# Altium schematic sheet Power.SchDoc(Power)
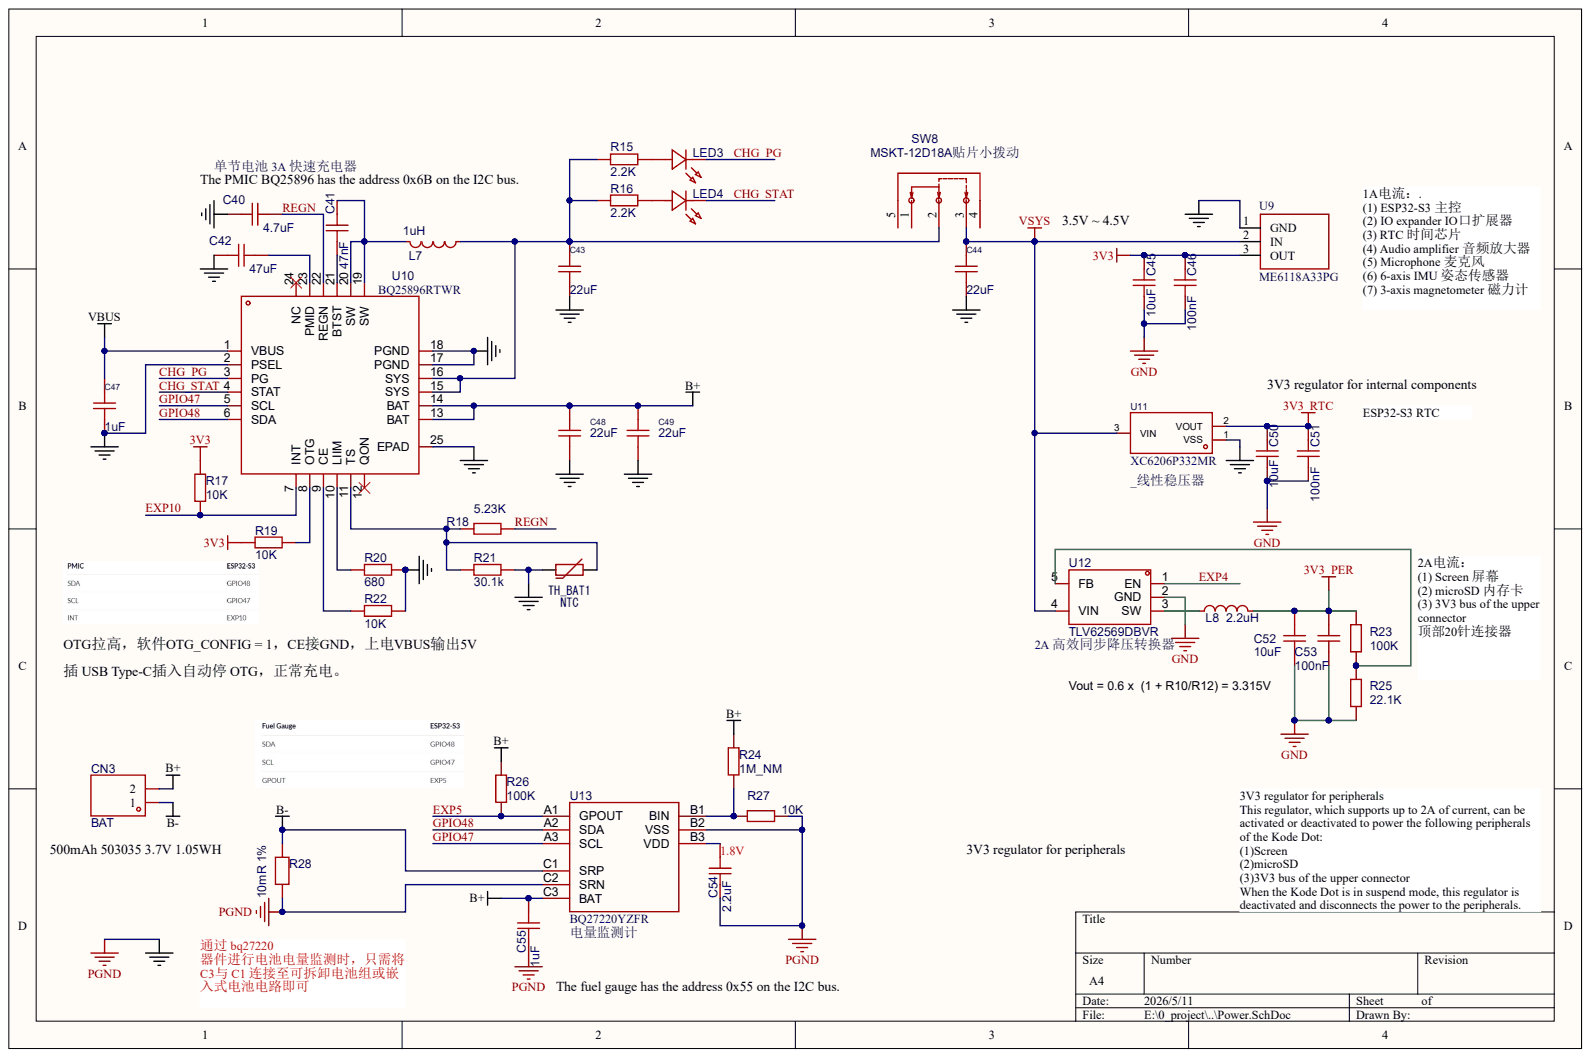
[diagram p0: Power.SchDoc]

## Parts
C40  4.7uF
C41  47nF
C42  47uF
C43  22uF
C44  22uF
C45  10uF
C46  100nF
C47  1uF
C48  value 22uF  (hidden comment: 1uF)
C49  value 22uF  (hidden comment: 1uF)
C50  10uF
C51  100nF
C52  10uF
C53  100nF
C54  2.2uF
C55  1uF
CN3  BAT
L7  1uH
L8  2.2uH
LED3  XL-1005UGC  (value not drawn)
LED4  XL-1005UGC  (value not drawn)
R15  2.2K
R16  2.2K
R17  10K
R18  5.23K
R19  10K
R20  680
R21  30.1k
R22  10K
R23  100K
R24  1M_NM
R25  22.1K
R26  100K
R27  10K
R28  10mR 1%
SW8  MSKT-12D18A贴片小拨动
TH_BAT1  NTC
U9  ME6118A33PG
U10  BQ25896RTWR
U11  XC6206P332MR
U12  TLV62569DBVR  Output Current (Max): 2A
U13  BQ27220YZFR

## Nets
3V3: C45.2 C46.2 R17.2 R19.1 U9.3
3V3_PER: C52.2 C53.2 L8.2 R23.1
3V3_RTC: C50.2 C51.2 U11.2
B+: C48.2 C49.2 C55.2 CN3.2 R24.2 R26.1 U10.13(BAT) U10.14(BAT) U13.C3(BAT)
B-: CN3.1 R28.2 U13.C1(SRP)
GND: C40.1 C42.1 C43.1 C44.1 C45.1 C46.1 C47.1 C48.1 C49.1 C50.1 C51.1 C52.1 C53.1 C54.1 C55.1 R20.2 R21.2 R22.2 R25.2 R27.2 R28.1 TH_BAT1.1 U9.1 U10.2(PSEL) U10.17(PGND) U10.18(PGND) U10.25(EPAD) U11.1 U12.2(GND) U13.B2(VSS) U13.C2(SRN)
VBUS: C47.2 U10.1(VBUS)
VSYS: C44.2 SW8.3 U9.2 U11.3 U12.4(VIN)
CHG_PG: LED3.1 U10.3(PG)
CHG_STAT: LED4.1 U10.4(STAT)
EXP4: U12.1(EN)
EXP5: R26.2 U13.A1(GPOUT)
EXP10: R17.1 U10.7(INT)
GPIO47: U10.5(SCL) U13.A3(SCL)
GPIO48: U10.6(SDA) U13.A2(SDA)
REGN: C40.2 R18.2 U10.22(REGN)
NetC41_1: C41.1 U10.21(BTST)
NetC41_2: C41.2 L7.2 U10.19(SW) U10.20(SW)
NetC42_2: C42.2 U10.23(PMID)
NetC43_2: C43.2 L7.1 R15.1 R16.2 SW8.2 U10.15(SYS) U10.16(SYS)
NetC54_2: C54.2 U13.B3(VDD)
NetL8_1: L8.1 U12.3(SW)
NetLED3_2: LED3.2 R15.2
NetLED4_2: LED4.2 R16.1
NetR18_1: R18.1 R21.1 TH_BAT1.2 U10.11(TS)
NetR19_2: R19.2 U10.8(OTG)
NetR20_1: R20.1 U10.10(LIIM)
NetR22_1: R22.1 U10.9(CE)
NetR23_2: R23.2 R25.1 U12.5(FB)
NetR24_1: R24.1 R27.1 U13.B1(BIN)

Not connected: SW8 1 4 5; U10 12(QON) 24(NC)

## Library data of the parts
C40 C54 C55 = (no symbol name): Manufacturer: SAMSUNG; Symbol: 4.7u; Device: CL05A475MP5NRNC; Add into BOM: yes; Origin Footprint: C0402; Supplier Part: C23733; Manufacturer Part: CL05A475MP5NRNC; Supplier Footprint: 0402; JLCPCB Part Class: Basic Part; Datasheet: https://atta.szlcsc.com/upload/public/pdf/source/20160103/1457707710457.pdf; Supplier: LCSC; Convert to PCB: yes
  C40: Value: 4.7uF
  C54: Value: 2.2uF
  C55: Value: 1uF
C41 = (no symbol name): Manufacturer: SAMSUNG; Device: CL05A475MP5NRNC; Add into BOM: yes; Origin Footprint: C0402; Symbol: 47nF; Supplier Part: C23733; Manufacturer Part: CL05A475MP5NRNC; Supplier Footprint: 0402; JLCPCB Part Class: Basic Part; Datasheet: https://atta.szlcsc.com/upload/public/pdf/source/20160103/1457707710457.pdf; Supplier: LCSC; Convert to PCB: yes; Value: 47nF
C42 = (no symbol name): Manufacturer: SAMSUNG; Symbol: 47u; Device: CL05A475MP5NRNC; Add into BOM: yes; Origin Footprint: C0603; Supplier Part: C23733; Manufacturer Part: CL05A475MP5NRNC; Supplier Footprint: 0603; JLCPCB Part Class: Basic Part; Datasheet: https://atta.szlcsc.com/upload/public/pdf/source/20160103/1457707710457.pdf; Supplier: LCSC; Convert to PCB: yes; Value: 47uF
C43 C44 C47-C49 = (no symbol name): Symbol: GRM155R71H104KE14D; Capacitance: 100nF; AddInBom: yes; ModelId: 36a51122f27c49738f86b3bfa98863e0; ModelName: C0402_L1.0-W0.5-H0.5; ModelTransform: 39.37,19.685,0,0,0,0,0,0,0; Voltage Rated: 50V; Temperature Coefficient: X7R; Device: GRM155R71H104KE14D; Supplier: LCSC; Manufacturer Part: GRM155R71H104KE14D; Supplier Part: C77020; Supplier Footprint: 0402; Datasheet: https://atta.szlcsc.com/upload/public/pdf/source/20180326/C77020_39BD49C951FF1AF0DB1F1FAC5AC1E401.pdf; ConvertToPcb: yes; FootprintName: R0402
  C43 C44: Value: 22uF
  C47: Value: 1uF
C45 C50 C52 = (no symbol name): Symbol: capacitance; Device: CL10A106KP8NNNC; AddInBom: yes; ModelId: ac125912035d415993ca9572d50418b1; ModelName: C0603_L1.6-W0.8-H0.8; ModelTransform: 62.992,31.496,0,0,0,0,0,0,0; Voltage Rated: 10V; Temperature Coefficient: X5R; SupplierPart: C19702; ManufacturerPart: CL10A106KP8NNNC; Supplier Footprint: 0603; JLCPCB Part Class: Basic Part; Datasheet: https://atta.szlcsc.com/upload/public/pdf/source/20160218/1457707763339.pdf; Supplier: LCSC; ConvertToPcb: yes; FootprintName: C0603; Value: 10uF
C46 C51 C53 = (no symbol name): Symbol: capacitance; Device: CL05B104KO5NNNC; AddInBom: yes; ModelId: 36a51122f27c49738f86b3bfa98863e0; ModelName: C0402_L1.0-W0.5-H0.5; ModelTransform: 39.37,19.685,0,0,0,0,0,0,0; Voltage Rated: 16V; Temperature Coefficient: X7R; SupplierPart: C1525; ManufacturerPart: CL05B104KO5NNNC; Supplier Footprint: 0402; JLCPCB Part Class: Basic Part; Datasheet: https://atta.szlcsc.com/upload/public/pdf/source/20160218/1457707763339.pdf; Supplier: LCSC; ConvertToPcb: yes; FootprintName: C0402; Value: 100nF
CN3 = (no symbol name): Manufacturer: BOOMELE; Manufacturer Part: 1.25T-2AW; Convert to PCB: yes; Origin Footprint: CONN-TH_2P-P1.25_1.25T-2AW; Symbol: BAT; Device: BAT; Supplier: LCSC; Supplier Part: C145979; JLCPCB Part Class: Extended Part; Add into BOM: yes
L7 = (no symbol name): Manufacturer: Vishay-Dale; Circuits: 1; AddInBom: yes; JLCPCB Part Class: Extended Part
L8 = (no symbol name): Symbol: FTC322510S2R2MBCA; AddInBom: yes; ModelId: 5b2886c109614f90b7af787bbb7d2dda; ModelName: IND-SMD_L3.2-W2.5-H2.0_82103C; ModelTransform: 125.984,98.425,0,0,0,0,0,0,0; Rated Current: 3.7A; Saturation Current (Isat): 4A; Device: FTC322510S2R2MBCA; SupplierPart: C18724399; ManufacturerPart: FTC322510S2R2MBCA; Supplier Footprint: SMD,2.5x3.2mm; Datasheet: https://atta.szlcsc.com/upload/public/pdf/source/20240918/5AD8A1A0D9C2859F32750FF2CC514AFA.pdf; Supplier: LCSC; ConvertToPcb: yes; FootprintName: IND-SMD_L3.2-W2.5_82103C; Value: 2.2uH
LED3 LED4 = (no symbol name): Symbol: XL-1005UGC_C2856703; AddInBom: yes; ModelId: 080bb9bd520f4b34ac21e218e7b036d6; ModelName: LED0402_L1.0-W0.5-H0.2; ModelTransform: 40.1574,19.685,0,0,0,0,0,-0.0005,0; Dominant Wavelength: 516nm; Peak Wavelength: 531nm; Forward Current: 25mA; Power Dissipation: 80mW; Device: XL-1005UGC; SupplierPart: C2856703; ManufacturerPart: XL-1005UGC; Supplier Footprint: 0402; JLCPCB Part Class: Extended Part; Datasheet: https://atta.szlcsc.com/upload/public/pdf/source/20221102/FF8D6BC2225363A60A01D46BB802F217.pdf; Supplier: LCSC; ConvertToPcb: yes; FootprintName: LED0402-RD_GREEN; Color Temperature: -
R15-R22 R24 R26 R27 = (no symbol name): Device: 0402WGF4990TCE; AddInBom: yes; ModelId: 671aefced39d4c318694bba8bd8efd39; ModelName: R0402_L1.0-W0.5-H0.5; ModelTransform: 39.37,19.685,0,0,0,0,0,0.0005,0; Power(Watts): 62.5mW; Overload Voltage (Max): 50V; Symbol: 0402WGF4990TCE; SupplierPart: C4125; ManufacturerPart: 0402WGF4990TCE; Supplier Footprint: 0402; JLCPCB Part Class: Extended Part; Datasheet: https://atta.szlcsc.com/upload/public/pdf/source/20200306/C422600_1E6D84923E4A46A82E41ADD87F860B5C.pdf; Supplier: LCSC; ConvertToPcb: yes; FootprintName: R0402
  R15 R16: Value: 2.2K
  R17 R19 R22 R27: Value: 10K
  R18: Value: 5.23K
  R20: Value: 680
  R21: Value: 30.1k
  R24: Value: 1M_NM
  R26: Value: 100K
R23 = (no symbol name): Device: 0402WGF1003TCE; AddInBom: yes; ModelId: 671aefced39d4c318694bba8bd8efd39; ModelName: R0402_L1.0-W0.5-H0.5; ModelTransform: 39.37,19.685,0,0,0,0,0,0.0005,0; Power(Watts): 62.5mW; Overload Voltage (Max): 50V; SupplierPart: C25741; ManufacturerPart: 0402WGF1003TCE; Supplier Footprint: 0402; JLCPCB Part Class: Basic Part; Datasheet: https://atta.szlcsc.com/upload/public/pdf/source/20200306/C422600_1E6D84923E4A46A82E41ADD87F860B5C.pdf; Supplier: LCSC; ConvertToPcb: yes; FootprintName: R0402; Value: 100K
R25 = (no symbol name): Device: 0402WGF2212TCE; AddInBom: yes; ModelId: 671aefced39d4c318694bba8bd8efd39; ModelName: R0402_L1.0-W0.5-H0.5; ModelTransform: 39.37,19.685,0,0,0,0,0,0.0005,0; Power(Watts): 62.5mW; Overload Voltage (Max): 50V; SupplierPart: C43473; ManufacturerPart: 0402WGF2212TCE; Supplier Footprint: 0402; JLCPCB Part Class: Extended Part; Datasheet: https://atta.szlcsc.com/upload/public/pdf/source/20200306/C422600_1E6D84923E4A46A82E41ADD87F860B5C.pdf; Supplier: LCSC; ConvertToPcb: yes; FootprintName: R0402; Value: 22.1K
R28 = (no symbol name): Symbol: HOLR1206-1W-10MR-1%-75PPM; AddInBom: yes; ModelId: 89a1f13db2384da588040e0b3c55bf66; ModelName: R1206_L3.2-W1.6-H0.6; ModelTransform: 125.984,62.992,0,0,0,0,0,0,0; Power(Watts): 1W; Device: HoLR1206-1W-10mR-1%-75ppm; Supplier: LCSC; Supplier Part: C601097; Manufacturer Part: HoLR1206-1W-10mR-1%-75ppm; Supplier Footprint: 1206; Datasheet: https://atta.szlcsc.com/upload/public/pdf/source/20200610/C601097_3C3A0FBF302E16C37BD368779C6D6328.pdf; ConvertToPcb: yes; FootprintName: R1206
SW8 = (no symbol name): Manufacturer: SHOU HAN; Manufacturer Part: SK12D07VG4; Symbol: POWER; Convert to PCB: yes; Origin Footprint: SW-TH_SK12D07VG4; Device: POWER; Supplier: LCSC; Supplier Part: C393937; JLCPCB Part Class: Extended Part; Add into BOM: yes
TH_BAT1 = (no symbol name): Manufacturer: TDK; Manufacturer Part: NTCG163JF103FT1; nameAlias: Value; Supplier: LCSC; Supplier Part: C76589; JLCPCB Part Class: Extended Part; spicePre: R; spiceSymbolName: NTCG163JF103FT1
U11 = (no symbol name): Symbol: XC6206P332MR_C347376
U12 = (no symbol name): AddInBom: yes; ModelId: 0a2a1b8f50944f73965918ad6e5fe167; ModelName: SOT-23-5_L2.9-W1.6-H1.1-LS2.8-P0.95; ModelTransform: 114.173,109.636,0,180,0,0,0,0,0; Input Voltage: 2.5V~5.5V; Output Voltage: 600mV~5.5V; Switching Frequency: 1.5MHz; Symbol: TLV62569DBVR; Device: TLV62569DBVR; LCSC Part Name: TLV62569DBVR; SupplierPart: C141836; ManufacturerPart: TLV62569DBVR; Supplier Footprint: SOT-23-5; Datasheet: https://www.ti.com/cn/lit/gpn/tlv62569; Supplier: LCSC; ConvertToPcb: yes; FootprintName: SOT-23-5_L3.0-W1.7-P0.95-LS2.8-BR; Number of Outputs: -
U9 U10 U13 = (no symbol name)
  U10: Symbol: BQ25896RTWR
  U13: Symbol: BQ27220YZFR
